# Altium schematic sheet Sinalização.SchDoc
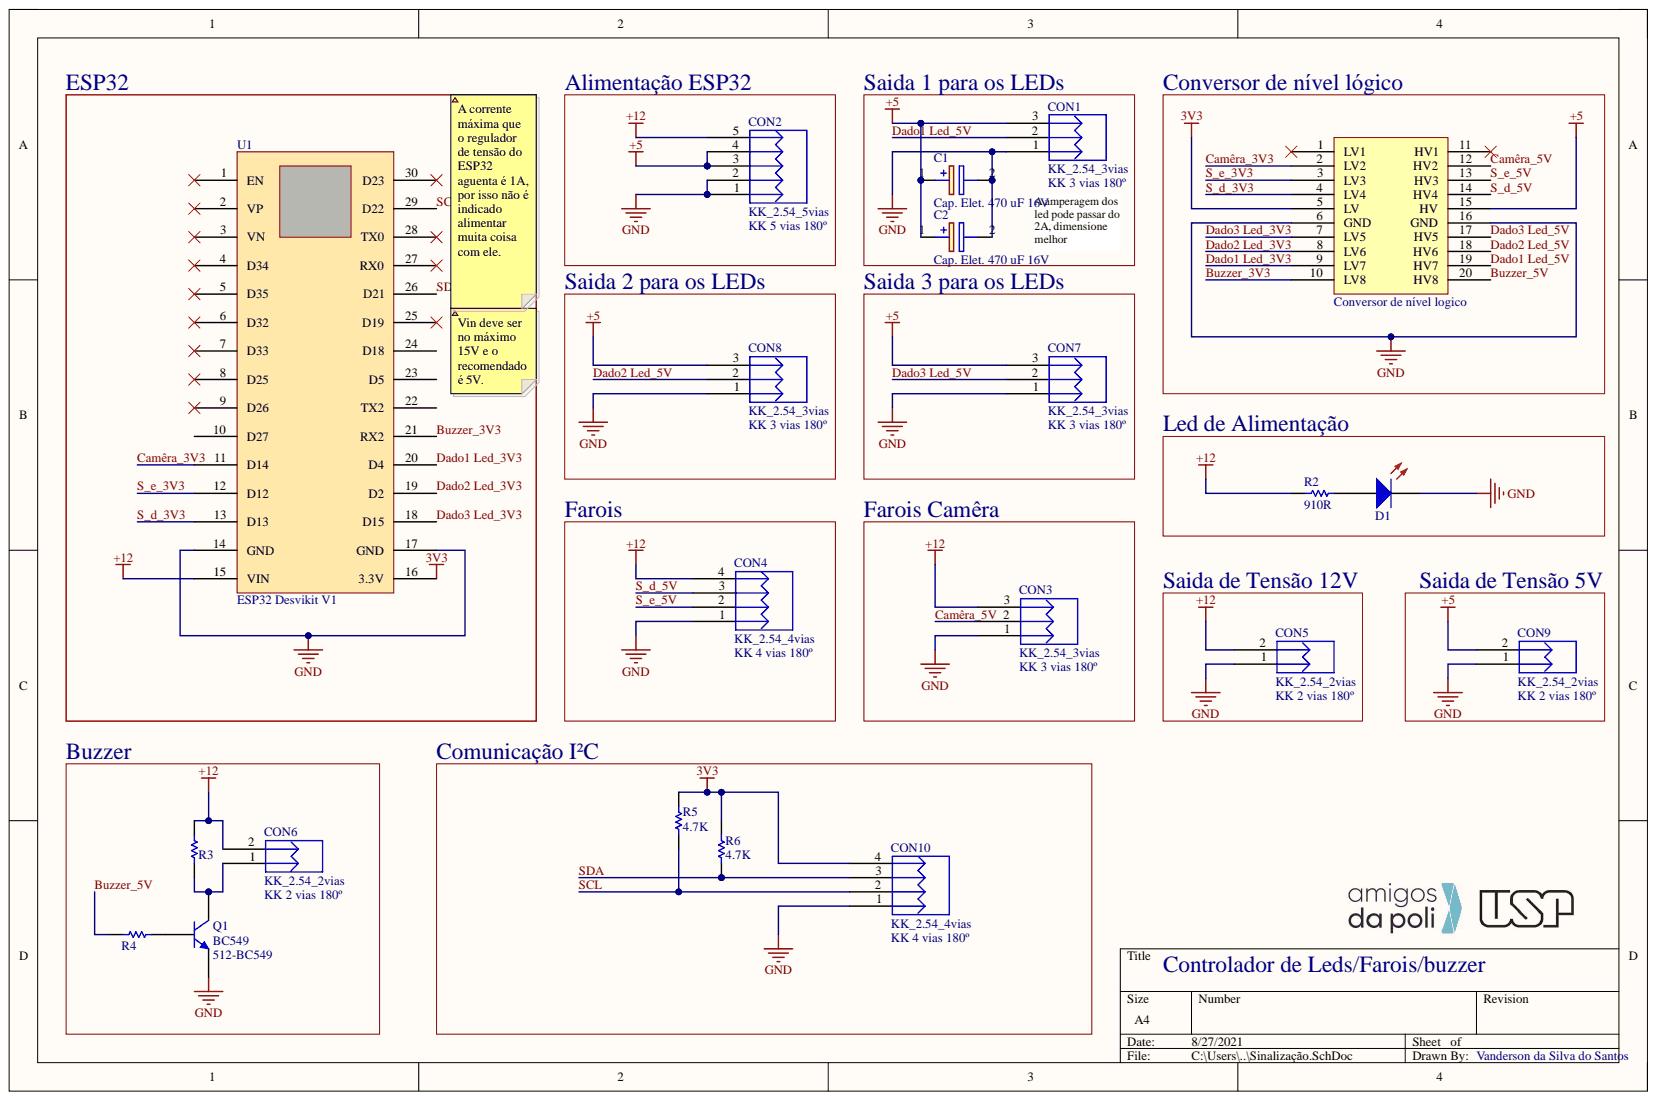
[diagram p0: Sinalização.SchDoc]

## Parts
C1  Cap. Elet. 470 uF 16V  [Cap. Elet. 470uF 16V]
C2  Cap. Elet. 470 uF 16V  [Cap. Elet. 470uF 16V]
CON1  KK_2.54_3vias  Valor: KK 3 vias 180º  [KK_3vias_180º]
CON2  KK_2.54_5vias  Valor: KK 5 vias 180º  [KK_5vias_180°]
CON3  KK_2.54_3vias  Valor: KK 3 vias 180º  [KK_3vias_180º]
CON4  KK_2.54_4vias  Valor: KK 4 vias 180º  [KK_4vias_180°]
CON5  KK_2.54_2vias  Valor: KK 2 vias 180º  [KK_2VIAS_180º]
CON6  KK_2.54_2vias  Valor: KK 2 vias 180º  [KK_2VIAS_180º]
CON7  KK_2.54_3vias  Valor: KK 3 vias 180º  [KK_3vias_180º]
CON8  KK_2.54_3vias  Valor: KK 3 vias 180º  [KK_3vias_180º]
CON9  KK_2.54_2vias  Valor: KK 2 vias 180º  [KK_2VIAS_180º]
CON10  KK_2.54_4vias  Valor: KK 4 vias 180º  [KK_4vias_180°]
D1  LED 5MM RED  [LED 5MM RED]  (value not drawn)
Q1  BC549  Supplier Part Number 1: 512-BC549  [TO92]
R2  Resistance (Ohms): 910R  [RES 470R 1/4W CARBON FILM]  (hidden comment: RES 470R 1/4W CARBON FILM)
R3  RES 1/4W CARBON FILM  [RES 10K 1/4W CARBON FILM]  (value not drawn)
R4  RES 1/4W CARBON FILM  [RES 10K 1/4W CARBON FILM]  (value not drawn)
R5  4.7K  [RES 4.7K 1/4W CARBON FILM]
R6  4.7K  [RES 4.7K 1/4W CARBON FILM]
U1  value ESP32 Desvikit V1  [ESP32_Desvikit_v1]  (hidden comment: microcontrolador)
  Conversor de nível logico  [Conversor de nível lógico]

## Nets
3V3: CON10.4 R5.1 R6.1 U1.16(3.3V) .5(LV)
+5: C1.1 C2.1 CON1.3 CON2.3 CON2.4 CON7.3 CON8.3 CON9.2 .15(HV)
+12: CON2.5 CON3.3 CON4.4 CON5.2 CON6.2 R2.2 R3.1 U1.15(VIN)
GND: C1.2 C2.2 CON1.1 CON2.1 CON2.2 CON3.1 CON4.1 CON5.1 CON7.1 CON8.1 CON9.1 CON10.1 D1.2 Q1.3 U1.14(GND) U1.17(GND) .6(GND) .16(GND)
Net_1: .1(LV1)
Net_11: .11(HV1)
Buzzer_3V3: U1.21(RX2) .10(LV8)
Buzzer_5V: R4.1 .20(HV8)
Camêra_3V3: U1.11(D14) .2(LV2)
Camêra_5V: CON3.2 .12(HV2)
Dado1 Led_3V3: U1.20(D4) .9(LV7)
Dado1 Led_5V: CON1.2 .19(HV7)
Dado2 Led_3V3: U1.19(D2) .8(LV6)
Dado2 Led_5V: CON8.2 .18(HV6)
Dado3 Led_3V3: U1.18(D15) .7(LV5)
Dado3 Led_5V: CON7.2 .17(HV5)
S_d_3V3: U1.13(D13) .4(LV4)
S_d_5V: CON4.3 .14(HV4)
S_e_3V3: U1.12(D12) .3(LV3)
S_e_5V: CON4.2 .13(HV3)
SCL: CON10.2 R5.2 U1.29(D22)
SDA: CON10.3 R6.2 U1.26(D21)
NetCON6_1: CON6.1 Q1.1 R3.2
NetD1_1: D1.1 R2.1
NetQ1_2: Q1.2 R4.2

Not connected: U1 1(EN) 2(VP) 3(VN) 4(D34) 5(D35) 6(D32) 7(D33) 8(D25) 9(D26) 10(D27) 22(TX2) 23(D5) 24(D18) 25(D19) 27(RX0) 28(TX0) 30(D23)

## Library data of the parts
C1 C2 = Cap. Elet. 470 uF 16V: Aluminum Organic Polymer Capacitors 16volts 470uF ESR 10mohm; Manufacturer_Name: Panasonic; Manufacturer_Part_Number: 16SEPC470M; Arrow Part Number: 16SEPC470M; Arrow Price/Stock: https://www.arrow.com/en/products/16sepc470m/panasonic; Component Kind: Standard; Datasheet Link: http://industrial.panasonic.com/www-cgi/jvcr13pz.cgi?E+CI+4+AAB8010+16SEPC470M+8+WW; Height: 13mm; Library Name: esp32.SchLib; Mouser Part Number: 667-16SEPC470M; Mouser Price/Stock: https://www.mouser.co.uk/ProductDetail/Panasonic/16SEPC470M?qs=OE1iw1LrrPEdZuWyF2l%252B5w%3D%3D
CON1 CON3 CON7 CON8 = KK_2.54_3vias: Conector KK 2.54mm 3 vias; Descrição: Conector KK 3 vias com passo 2.54mm; Encapsulamento: PTH - 180º; Modelo: 3 vias - 180º; Component Kind: Standard; Library Name: Conectores_Sch.SchLib
CON2 = KK_2.54_5vias: Conector KK 2.54mm 5 vias; Descrição: Conector KK 3 vias com passo 2.54mm; Encapsulamento: PTH - 180º; Modelo: 3 vias - 180º; Component Kind: Standard; Library Name: Conectores_Sch.SchLib
CON4 CON10 = KK_2.54_4vias: Conector KK 2.54mm 4 vias; Descrição: Conector KK 3 vias com passo 2.54mm; Encapsulamento: PTH - 180º; Modelo: 3 vias - 180º; Component Kind: Standard; Library Name: Conectores_Sch.SchLib
CON5 CON6 CON9 = KK_2.54_2vias: Conector KK 2.54mm 2 vias; Descrição: Conector KK 3 vias com passo 2.54mm; Encapsulamento: PTH - 180º; Modelo: 2 vias - 180º; Component Kind: Standard; Library Name: Conectores_Sch.SchLib
D1 = LED 5MM RED: Manufacturer: Paralight; Manufacturer Part Number: IMP585; Bulb Size: 3mm; Current If Luminous Intensity: 2; Dominant Wavelength: 625; External Diameter: 2.9; External Length / Height: 4.6; Forward Current If: 20; Forward Voltage VF Max: 2.5; Lead Cross-section Depth: 0.5; LED / Lamp Size: 3mm / T-1; LED Mounting: Through Hole; LED Type: Standard; Lens Shape: Round; Luminous Intensity: 3; Luminous Intensity @ If Min: 0.7; Peak Forward Current: 7; PIV Max: 5; SVHC: No SVHC (15-Jun-2015); Viewing Angle: 60°; Voltage Vf Typ: 2.2V<!--FANDB--><div class="features-and-benefits-container"><div class="features-and-benefits-description"><span class="features-benefits-value">The L-934LID from Kingbright is a through hole round shape high efficiency red colour LED. The device is made of gallium arsenide phosphide on gallium phosphide orange light emitting diode. This LED is widely used because of low power consumption, long life and solid state reliability.</span></div><div class="features-and-benefits-list"><ul class="features-and-benefits-list-list"><li class="features-and-benefits-list-item">Standard bulb size of T-1 (3mm)</li><li class="features-and-benefits-list-item">Reliable and rugged</li><li class="features-and-benefits-list-item">Viewing angle is 60°</li><li class="features-and-benefits-list-item">Luminous intensity of 3mcd at 2mA</li><li class="features-and-benefits-list-item">Forward voltage is 2.0V</li><li class="features-and-benefits-list-item">Forward current (If) is 20mA</li><li class="features-and-benefits-list-item">Dominant wavelength is 625nm at IF=20mA</li><li class="features-and-benefits-list-item">Peak wavelength is 627nm at IF=20; Component Kind: Standard; ComponentLink1Description: http://loja.multcomercial.com.br/led-3mm-vermelho-difuso-l-314hd-paralight.html; ComponentLink1URL: http://loja.multcomercial.com.br/led-3mm-vermelho-difuso-l-314hd-paralight.html; Description: LED 5MM RED; Forward Current If Max: 20; Forward Voltage: 2; Lead Cross-section Width: 0.5; Lead Spacing: 2.54; LED Colour: Red; Library Name: esp32.SchLib; Luminous Intensity Typ: 3; Mounting Type: PCB Through Hole; MSL: -; No. of Pins: 2; Operating Temperature Max: 85; Operating Temperature Min: -40; Operating Temperature Range: -40°C to +85; Packaging: Each; Peak Wavelength: 625; Pricing 1: 1=0.15 (BRL); RoHS: YES; Stock 1: 35260; Supplier 1: Mult Comercial; Supplier Part Number 1: Led 3mm Vermelho Difuso L-314HD - Paralight; Wavelength Typ: 625
Q1 = BC549: TRANS NPN 30V 0.1A TO-92; Brand: Fairchild Semiconductor; Collector-Emitter Saturation Voltage: 200 mV; Configuration: Single; DC Collector/Base Gain hfe Min: 110; DC Current Gain hFE Max: 800; Emitter- Base Voltage VEBO: 5 V; Gain Bandwidth Product fT: 300 MHz; Maximum Power Dissipation: 0.5 W; Collector- Base Voltage VCBO: 30 V; Collector- Emitter Voltage VCEO Max: 30 V; Component Kind: Standard; ComponentLink1Description: https://datasheet.ciiva.com/982/bc546-982130.pdf; ComponentLink1URL: https://datasheet.ciiva.com/982/bc546-982130.pdf; ComponentLink2Description: https://datasheet.ciiva.com/17179/bc549-17179663.pdf; ComponentLink2URL: https://datasheet.ciiva.com/17179/bc549-17179663.pdf; Factory Pack Quantity: 2000; Manufacturer: Fairchild Semiconductor; Manufacturer Part Number: BC549; Maximum DC Collector Current: 0.1 A; Maximum Operating Temperature: + 150 C; Minimum Operating Temperature: - 65 C; Mounting Style: Through Hole; Package / Case: TO-92-3; Packaging: Bulk; Product Category: Bipolar Transistors - BJT; RoHS: Details; Series: BC549; Supplier 1: Mouser; Transistor Polarity: NPN; Library Name: PS_Balanca.SchLib
R2 = RES 470R 1/4W CARBON FILM: RES 470R OHM 1/4W 5% CARBON FILM; Manufacturer: Ty-Ohm; Manufacturer Part Number: CR25; Power (Watts): 0.25W, 1/4W; Temperature Coefficient: 0/ -500ppm/°C; Category: Resistors; Component Kind: Standard; ComponentLink1Description: http://loja.multcomercial.com.br/resistor-de-1-4-de-watt-cr25-5-de-tolerancia.html; ComponentLink1URL: http://loja.multcomercial.com.br/resistor-de-1-4-de-watt-cr25-5-de-tolerancia.html; Composition: Carbon Film; Features: Flame Retardant Coating, Safety; Height: -; Library Name: esp32.SchLib; Number of Terminations: 2; Operating Temperature: -55°C ~ 155°C; Package / Case: Axial; Packaging: Cut Tape (CT); Pricing 1: 1=0.20 (BRL); RoHS: RoHS Compliant; Size / Dimension: 0.091" Dia x 0.256" L (2.30mm x 6.50mm); Stock 1: 617543; Supplier 1: Mult Comercial; Supplier Device Package: Axial; Supplier Part Number 1: Resistor de Filme de Carbono 1/4 de Watt CR25 5% de tolerância - 0.22R Ω à 10M Ω; Tolerance: ±5%
R3 R4 = RES 1/4W CARBON FILM: RES ? OHM 1/4W 5% CARBON FILM; Manufacturer: Ty-Ohm; Manufacturer Part Number: CR25; Power (Watts): 0.25W, 1/4W; Temperature Coefficient: 0/ -500ppm/°C; Category: Resistors; Component Kind: Standard; ComponentLink1Description: http://loja.multcomercial.com.br/resistor-de-1-4-de-watt-cr25-5-de-tolerancia.html; ComponentLink1URL: http://loja.multcomercial.com.br/resistor-de-1-4-de-watt-cr25-5-de-tolerancia.html; Composition: Carbon Film; Features: Flame Retardant Coating, Safety; Height: -; Number of Terminations: 2; Operating Temperature: -55°C ~ 155°C; Package / Case: Axial; Packaging: Cut Tape (CT); Pricing 1: 1=0.20 (BRL); Resistance (Ohms): ?; RoHS: RoHS Compliant; Size / Dimension: 0.091" Dia x 0.256" L (2.30mm x 6.50mm); Stock 1: 617543; Supplier 1: Mult Comercial; Supplier Device Package: Axial; Supplier Part Number 1: Resistor de Filme de Carbono 1/4 de Watt CR25 5% de tolerância - 0.22R Ω à 10M Ω; Tolerance: ±5%; Library Name: Resistores_SCHLIB.SchLib
R5 R6 = RES 4.7K 1/4W CARBON FILM: RES 4.7K OHM 1/4W 5% CARBON FILM; Manufacturer: Ty-Ohm; Manufacturer Part Number: CR25; Power (Watts): 0.25W, 1/4W; Temperature Coefficient: 0/ -500ppm/°C; Category: Resistors; Component Kind: Standard; ComponentLink1Description: http://loja.multcomercial.com.br/resistor-de-1-4-de-watt-cr25-5-de-tolerancia.html; ComponentLink1URL: http://loja.multcomercial.com.br/resistor-de-1-4-de-watt-cr25-5-de-tolerancia.html; Composition: Carbon Film; Features: Flame Retardant Coating, Safety; Height: -; Library Name: esp32.SchLib; Number of Terminations: 2; Operating Temperature: -55°C ~ 155°C; Package / Case: Axial; Packaging: Cut Tape (CT); Pricing 1: 1=0.20 (BRL); Resistance (Ohms): ?; RoHS: RoHS Compliant; Size / Dimension: 0.091" Dia x 0.256" L (2.30mm x 6.50mm); Stock 1: 617543; Supplier 1: Mult Comercial; Supplier Device Package: Axial; Supplier Part Number 1: Resistor de Filme de Carbono 1/4 de Watt CR25 5% de tolerância - 0.22R Ω à 10M Ω; Tolerance: ±5%
U1 = ESP32 Desvikit v1: microcontrolador com moculo bluethoth e wifi; Component Kind: Standard; Library Name: esp32.SchLib
 = Conversor de nível logico: Component Kind: Standard; Library Name: esp32.SchLib
